# Altium schematic sheet MainBoard_Interface_ProyimityDetector.SchDoc
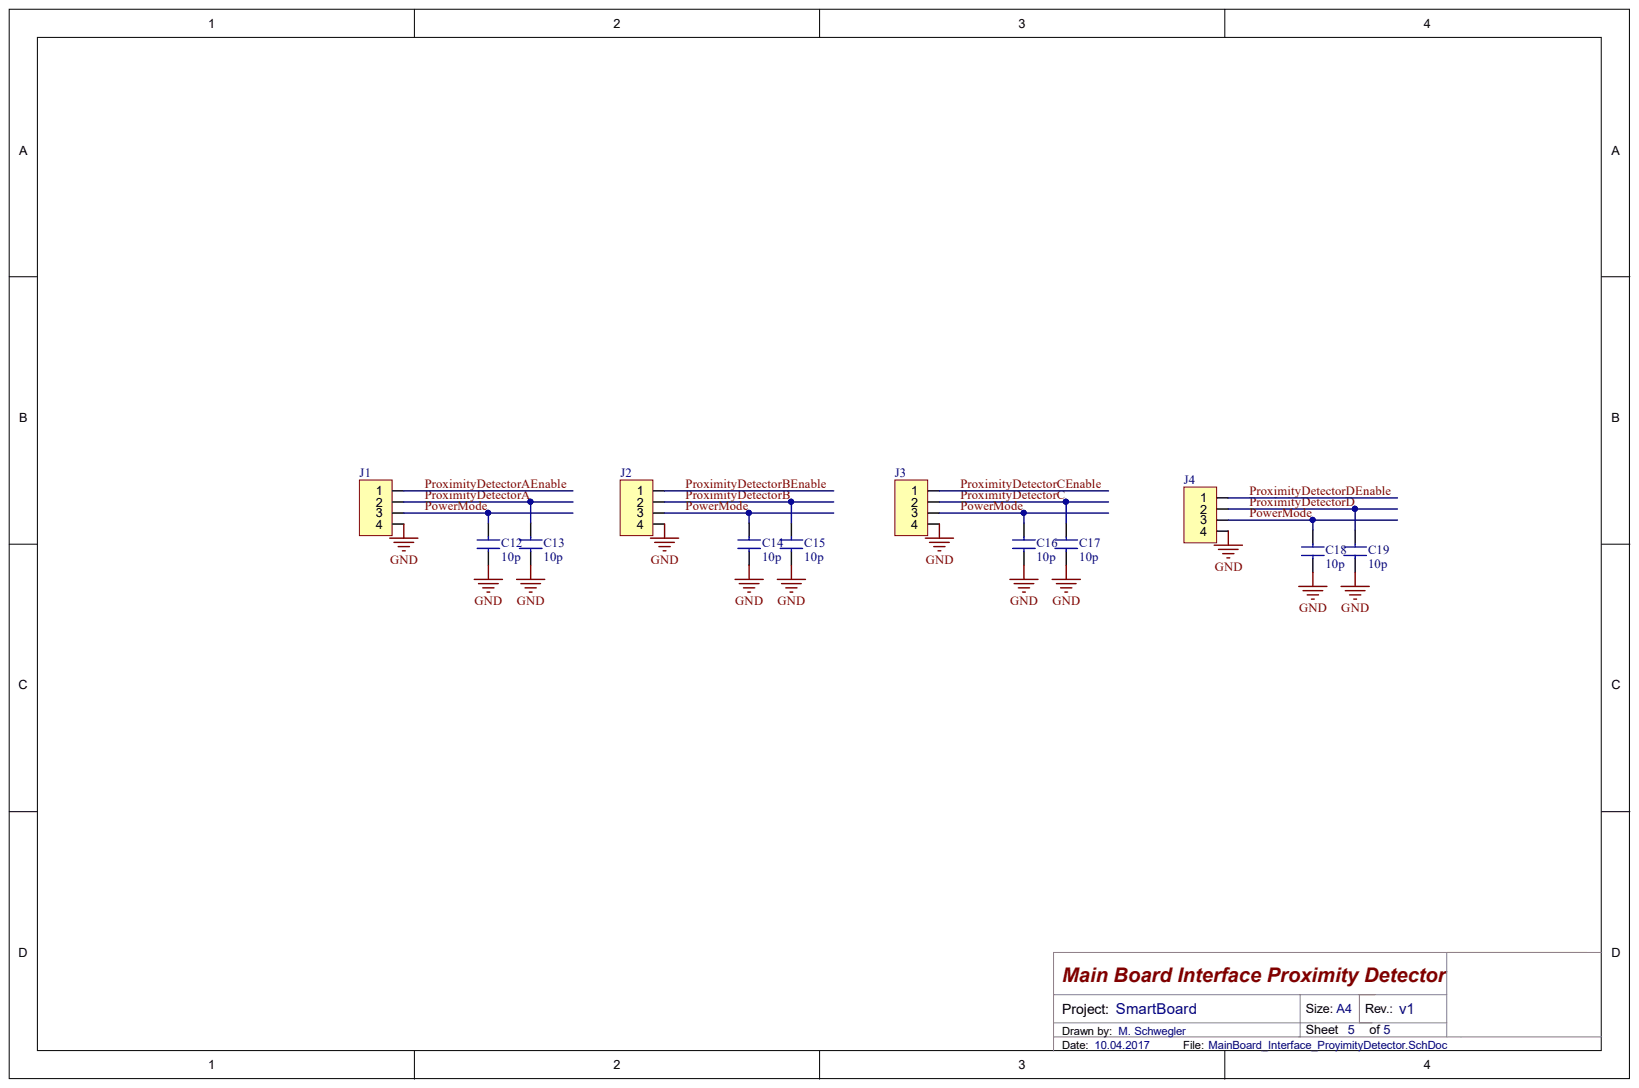
[diagram p0: MainBoard_Interface_ProyimityDetector.SchDoc]

## Parts
C12  10p  [0805_C]
C13  10p  [0805_C]
C14  10p  [0805_C]
C15  10p  [0805_C]
C16  10p  [0805_C]
C17  10p  [0805_C]
C18  10p  [0805_C]
C19  10p  [0805_C]
J1  Value  [CON_THT_4P_RM2.54]  (value not drawn)
J2  Value  [CON_THT_4P_RM2.54]  (value not drawn)
J3  Value  [CON_THT_4P_RM2.54]  (value not drawn)
J4  Value  [CON_THT_4P_RM2.54]  (value not drawn)

## Nets
GND: C12.1 C13.1 C14.1 C15.1 C16.1 C17.1 C18.1 C19.1 J1.4 J2.4 J3.4 J4.4
POWERMODE: C12.2 C14.2 C16.2 C18.2 J1.3 J2.3 J3.3 J4.3
PROXIMITYDETECTORA: C13.2 J1.2
PROXIMITYDETECTORAENABLE: J1.1
PROXIMITYDETECTORB: C15.2 J2.2
PROXIMITYDETECTORBENABLE: J2.1
PROXIMITYDETECTORC: C17.2 J3.2
PROXIMITYDETECTORCENABLE: J3.1
PROXIMITYDETECTORD: C19.2 J4.2
PROXIMITYDETECTORDENABLE: J4.1

## Library data of the parts
C12-C19 = C?: Normaler Kondensator; Component Kind: Standard; Library Name: MainBoard.SCHLIB
J1-J4 = CON_4P: Component Kind: Standard; Library Name: Sch_Lib.SchLib
